# Altium schematic sheet VHF_430.SchDoc
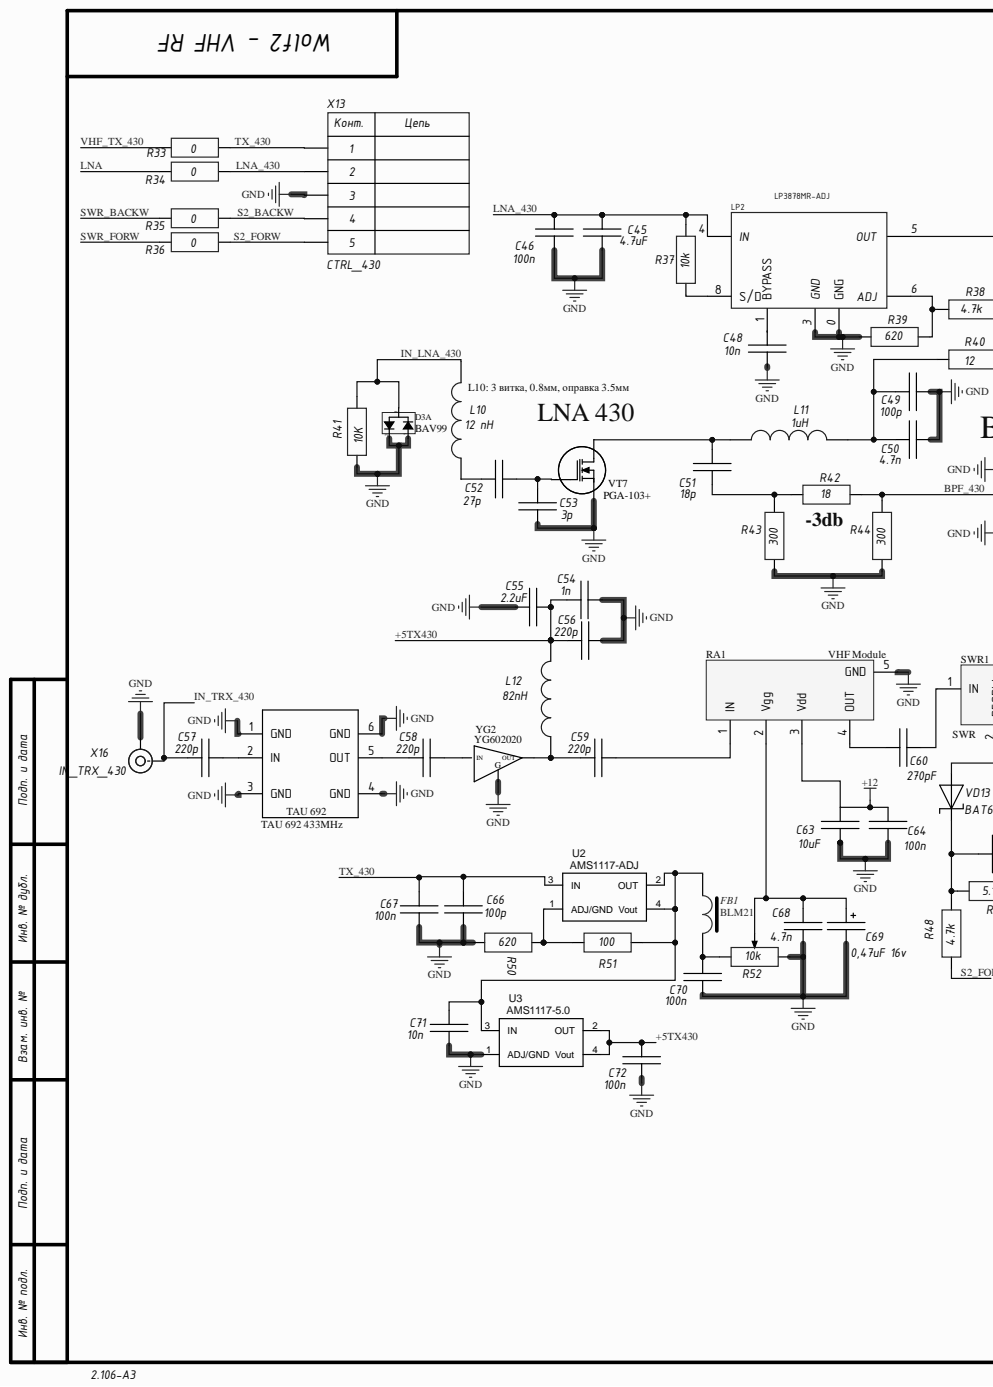
[diagram p0: VHF_430.SchDoc, left]
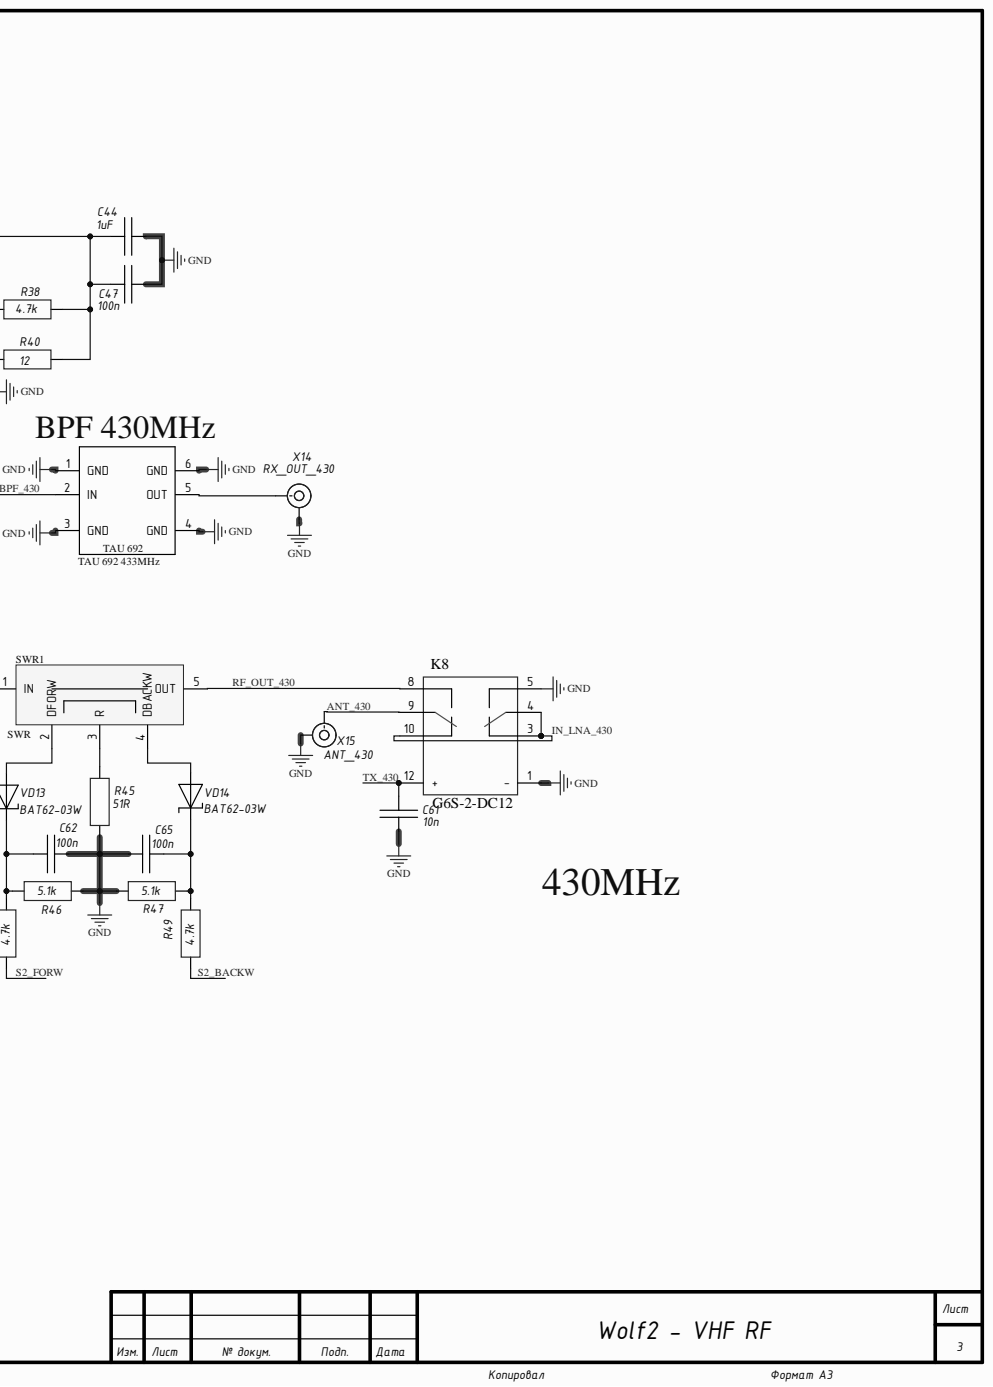
[diagram p1: VHF_430.SchDoc, right]

## Parts
C44  1uF  [CH0805C]
C45  4.7uF  [CH0805C]
C46  100n  [CH0805C]
C47  100n  [CH0805C]
C48  10n  [CH0805C]
C49  100p  [CH0805C]
C50  4.7n  [CH0805C]
C51  18p  [CH0805C]
C52  27p  [CH0805C]
C53  3p  [CH0805C]
C54  1n  [CH0805C]
C55  2.2uF  [CH0805C]
C56  220p  [CH0805C]
C57  220p  [CH0805C]
C58  220p  [CH0805C]
C59  220p  [CH0805C]
C60  270pF  [CH1206ATC]
C61  10n  [CH0805C]
C62  100n  [CH0805C]
C63  10uF  [CH1206C]
C64  100n  [CH0805C]
C65  100n  [CH0805C]
C66  100p  [CH0805C]
C67  100n  [CH0805C]
C68  4.7n  [CH0805C]
C69  0,47uF 16v  [TANTAL_B]
C70  100n  [CH0805C]
C71  10n  [CH0805C]
C72  100n  [CH0805C]
D3  BAV99  [SOT23_132]  (part A)
FB1  BLM21  [CH0805L]
K8  G6S-2-DC12  [G6S-2-DC12]
L10  12 nH  [CH1210L]
L11  1uH  [CH1210L]
L12  82nH  [CH1210L]
LP2  LP3878MR-ADJ  [SOP-8 gnd]
R33  0  [CH1206R]
R34  0  [CH1206R]
R35  0  [CH1206R]
R36  0  [CH1206R]
R37  10k  [CH0805R]
R38  4.7k  [CH0805R]
R39  620  [CH0805R]
R40  12  [CH2010R]
R41  10K  [CH2010R]
R42  18  [CH0805R]
R43  300  [CH0805R]
R44  300  [CH0805R]
R45  51R  [CH1206R]
R46  5.1k  [CH0805R]
R47  5.1k  [CH0805R]
R48  4.7k  [CH0805R]
R49  4.7k  [CH0805R]
R50  620  [CH0805R]
R51  100  [CH0805R]
R52  10k  [RES: 3296W]
RA1  VHF Module  [RA80H]
SWR1  SWR  [SWR_VHF]
TAU_1  TAU 692 433MHz  [TAU-692 433 MHz]
TAU_2  TAU 692 433MHz  [TAU-692 433 MHz]
U2  1117-ADJ  value AMS1117-ADJ  [LT1117CST-3.3]
U3  1117-5.0  value AMS1117-5.0  [LT1117CST-3.3]
VD13  BAT62-03W  [SOD323]
VD14  BAT62-03W  [SOD323]
VT7  PGA-103+  [SOT89_321_PGA103]
X13  CTRL_430  [CON: PLS-5]
X14  RX_OUT_430  [CON: u.Fl]
X15  ANT_430  [CON: SMA]
X16  IN_TRX_430  [CON: u.Fl]
YG2  YG602020  [SOT89_123]

## Nets
+12: C63.1 C64.2 RA1.3(Vdd)
GND: C44.2 C45.2 C46.2 C47.2 C48.2 C49.2 C50.2 C53.2 C54.1 C55.2 C56.1 C61.1 C62.1 C63.2 C64.1 C65.2 C66.2 C67.2 C68.1 C69.2 C70.1 C71.2 C72.1 D3.1 D3.2 K8.1(-) K8.5 LP2.0(GNG) LP2.3(GND) R39.2 R41.1 R43.2 R44.2 R45.1 R46.1 R47.2 R50.2 R52.3 RA1.5(GND) TAU_1.1(GND) TAU_1.3(GND) TAU_1.4(GND) TAU_1.6(GND) TAU_2.1(GND) TAU_2.3(GND) TAU_2.4(GND) TAU_2.6(GND) U3.1(ADJ/GND) VT7.2 X13.3 X14.2 X15.2 X16.2 YG2.2
+5TX430: C54.2 C55.1 C56.2 C72.2 L12.1 U3.2(OUT) U3.4(Vout)
ANT_430: K8.9 X15.1
BPF_430: R42.1 R44.1 TAU_1.2(IN)
IN_LNA_430: D3.3 K8.3 K8.4 K8.10 L10.1 R41.2
IN_TRX_430: C57.2 X16.1
LNA: R34.1
LNA_430: C45.1 C46.1 LP2.4(IN) R34.2 R37.1 X13.2
RF_OUT_430: K8.8 SWR1.5(OUT)
S2_BACKW: R35.2 R49.2 X13.4
S2_FORW: R36.2 R48.2 X13.5
SWR_BACKW: R35.1
SWR_FORW: R36.1
TX_430: C61.2 C66.1 C67.1 K8.12(+) R33.2 U2.3(IN) X13.1
VHF_TX_430: R33.1
NetC44_1: C44.1 C47.1 LP2.5(OUT) R38.1 R40.1
NetC48_1: C48.1 LP2.1(BYPASS)
NetC49_1: C49.1 C50.1 L11.1 R40.2
NetC51_1: C51.1 L11.2 VT7.1
NetC51_2: C51.2 R42.2 R43.1
NetC52_1: C52.1 C53.1 VT7.3
NetC52_2: C52.2 L10.2
NetC57_1: C57.1 TAU_2.2(IN)
NetC58_1: C58.1 YG2.1
NetC58_2: C58.2 TAU_2.5(OUT)
NetC59_1: C59.1 RA1.1(IN)
NetC59_2: C59.2 L12.2 YG2.3
NetC60_1: C60.1 RA1.4(OUT)
NetC60_2: C60.2 SWR1.1(IN)
NetC62_2: C62.2 R46.2 R48.1 VD13.2
NetC65_1: C65.1 R47.1 R49.1 VD14.2
NetC68_2: C68.2 C69.1 R52.2 RA1.2(Vgg)
NetC70_2: C70.2 FB1.1 R52.1
NetC71_1: C71.1 FB1.2 R51.1 U2.2(OUT) U2.4(Vout) U3.3(IN)
NetLP2_6: LP2.6(ADJ) R38.2 R39.1
NetLP2_8: LP2.8(S/D) R37.2
NetR45_2: R45.2 SWR1.3(R)
NetR50_1: R50.1 R51.2 U2.1(ADJ/GND)
NetSWR1_2: SWR1.2(DFORW) VD13.1
NetSWR1_4: SWR1.4(DBACKW) VD14.1
NetTAU 1_5: TAU_1.5(OUT) X14.1

## Library data of the parts
C44-C68 C70-C72 = Capacitor: Component Kind: Standard; Library Name: Discrete.SchLib
C69 = TantalCap: Component Kind: Standard; Library Name: Discrete.SchLib
FB1 = FB-1: Component Kind: Standard; Library Name: Discrete.SchLib
K8 = G6S-2-12VDC: Component Kind: Standard; Library Name: RU4PN.SchLib
L10-L12 = Inductor: Component Kind: Standard; Library Name: Discrete.SchLib
LP2 = LP3878-ADJ: LP3878-ADJ HSOP-8; Component Kind: Standard; Library Name: RU4PN.SchLib
R33-R51 = Resistor: Component Kind: Standard; Library Name: Discrete.SchLib
R52 = ADJ resistor: Component Kind: Standard; Library Name: Discrete.SchLib
RA1 = RA80H: Component Kind: Standard; Description: RA80H; Library Name: RU4PN.SchLib
SWR1 = SWR_VHF: Component Kind: Standard; Description: SWR_VHF; Library Name: RU4PN.SchLib
U2 = LT1117CST-3.3: LT1117CST-ADJ; Component Kind: Standard
U3 = LT1117CST-3.3: LT1117CST-5.0; Component Kind: Standard
VD13 VD14 = Diod Schottky: Component Kind: Standard; Library Name: Diods.SchLib
VT7 = N: Component Kind: Standard; Library Name: Transistor.SchLib
X13 = 5-Connector: Component Kind: Standard; Library Name: Connectors.SchLib
X14 X16 = u.Fl: RF; Manufacturer: Hirose Electric Co Ltd; Model: U.FL-R-SMT(01); Component Kind: Standard; Library Name: Connectors.SchLib
X15 = SMA: RF; Component Kind: Standard; Library Name: Connectors.SchLib
D3 = BAV99: Component Kind: Standard; Library Name: Analog.SchLib
TAU_1 TAU_2 = TAU 692 433MHz: Component Kind: Standard; Description: TAU 692 433MHz; Library Name: RU4PN.SchLib
YG2 = YG602020: Component Kind: Standard; Library Name: RU4PN.SchLib
